# Altium schematic sheet 2023_Clippy.SchDoc
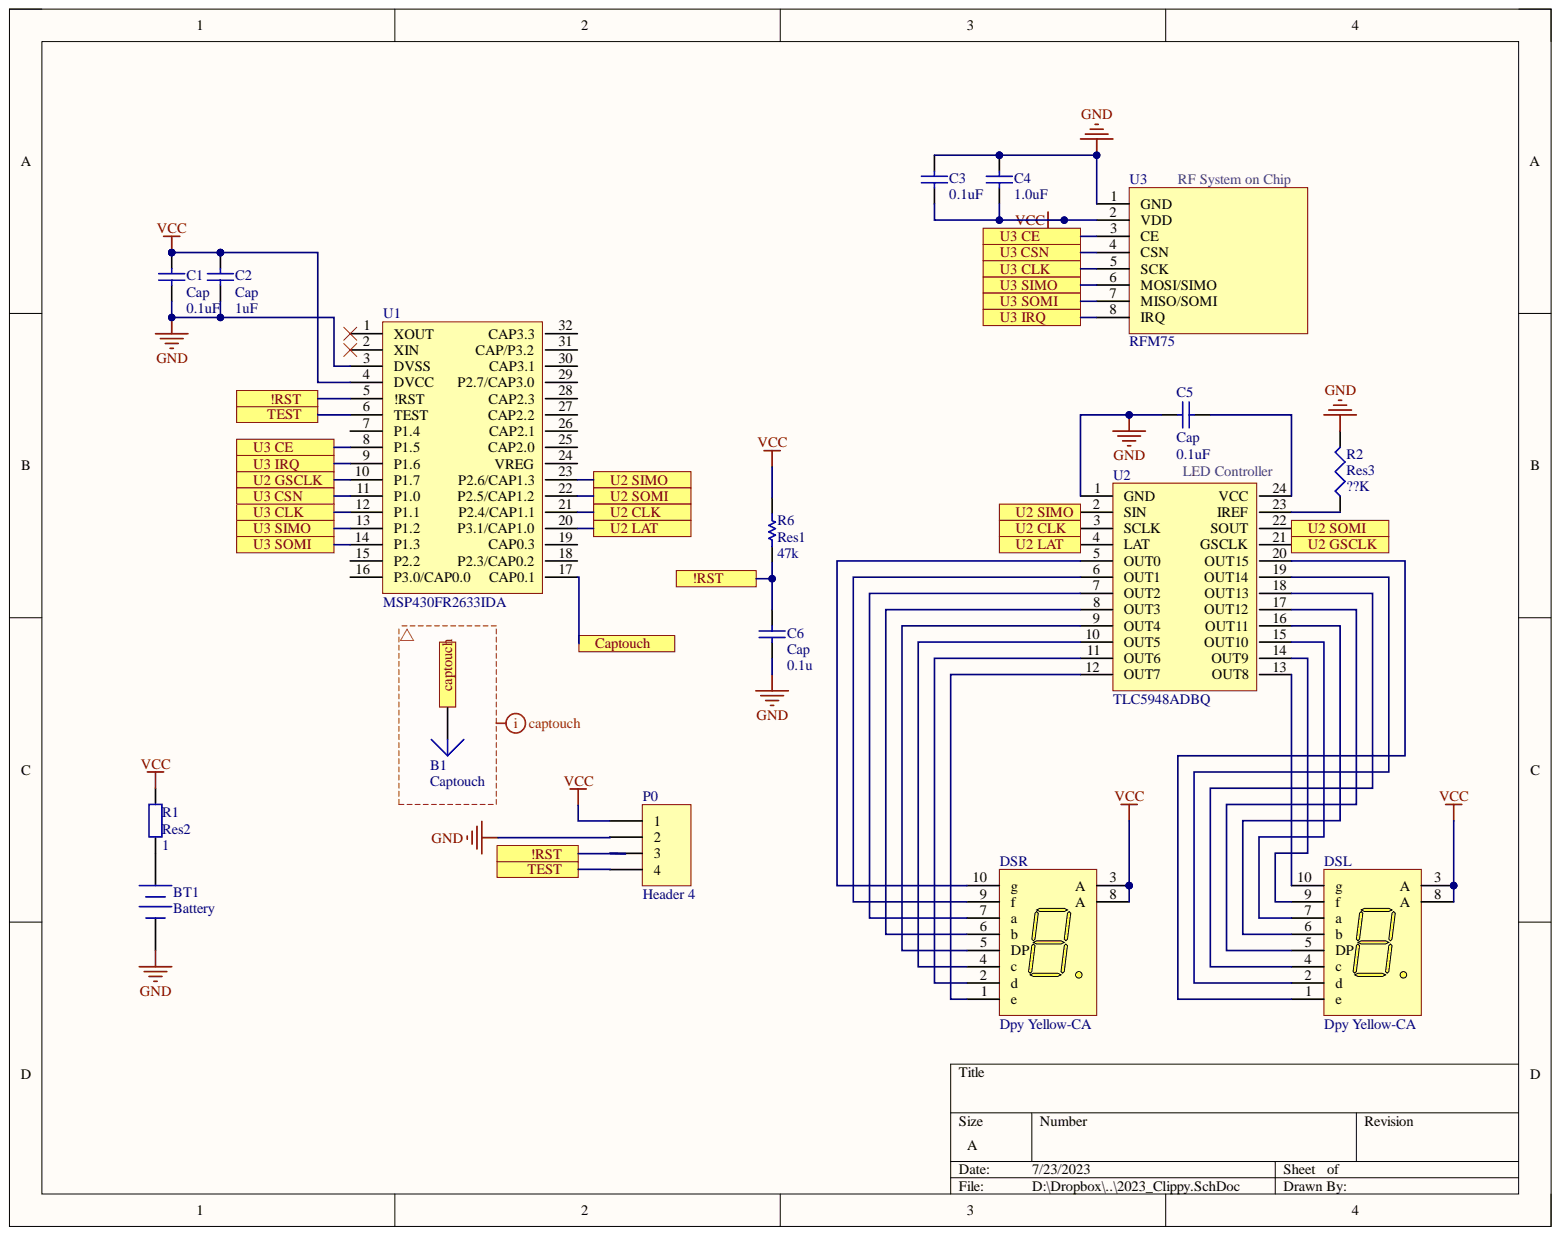
[diagram p0: 2023_Clippy.SchDoc]

## Parts
B1  Captouch  [captouch1.5mm]
BT1  Battery  [Keystone 1050]
C1  Cap  value 0.1uF  [CAPC1608(0603)]
C2  Cap  value 1uF  [CAPC1608(0603)]
C3  value 0.1uF  [CAPC1608(0603)]  (hidden comment: Cap)
C4  value 1.0uF  [CAPC1608(0603)]  (hidden comment: Cap)
C5  Cap  value 0.1uF  [CAPC1608(0603)]
C6  Cap  value 0.1u  [CAPC1608(0603)]
DSL  Dpy Yellow-CA  PackageReference: A  [7SEG]
DSR  Dpy Yellow-CA  PackageReference: A  [7SEG]
P0  Header 4  [1x4 2mm Header]
R1  Res2  value 1  [RESC5025(2010)]
R2  Res3  value ??K  [J1-0603]
R6  Res1  value 47k  [RESC1608(0603)]
U1  MSP430FR2633IDA  [TSOP65P810X120-32M]
U2  TLC5948ADBQ  [SOP64P600X175-24M]
U3  RFM75  [RFM75]

## Ports
!RST, captouch, Captouch, TEST, U2 CLK, U2 GSCLK, U2 LAT, U2 SIMO, U2 SOMI, U3 CE, U3 CLK, U3 CSN, U3 IRQ, U3 SIMO, U3 SOMI

## Nets
GND: BT1.2 C1.2 C2.1 C3.2 C4.2 C5.1 C6.1 P0.2 R2.2 U1.3(DVSS) U2.1(GND) U3.1(GND)
VCC: C1.1 C2.2 C3.1 C4.1 DSL.3(A) DSL.8(A) DSR.3(A) DSR.8(A) P0.1 R1.2 R6.2 U1.4(DVCC) U3.2(VDD)
!RST: C6.2 P0.3 R6.1 U1.5(!RST) ; port !RST
captouch: B1.1 U1.17(CAP0.1) ; port Captouch, port captouch
TEST: P0.4 U1.6(TEST) ; port TEST
U2 CLK: U1.21(P2.4/CAP1.1) U2.3(SCLK) ; port U2 CLK
U2 GSCLK: U1.10(P1.7) U2.21(GSCLK) ; port U2 GSCLK
U2 LAT: U1.20(P3.1/CAP1.0) U2.4(LAT) ; port U2 LAT
U2 SIMO: U1.23(P2.6/CAP1.3) U2.2(SIN) ; port U2 SIMO
U2 SOMI: U1.22(P2.5/CAP1.2) U2.22(SOUT) ; port U2 SOMI
U3 CE: U1.8(P1.5) U3.3(CE) ; port U3 CE
U3 CLK: U1.12(P1.1) U3.5(SCK) ; port U3 CLK
U3 CSN: U1.11(P1.0) U3.4(CSN) ; port U3 CSN
U3 IRQ: U1.9(P1.6) U3.8(IRQ) ; port U3 IRQ
U3 SIMO: U1.13(P1.2) U3.6(MOSI/SIMO) ; port U3 SIMO
U3 SOMI: U1.14(P1.3) U3.7(MISO/SOMI) ; port U3 SOMI
NetBT1_1: BT1.1 R1.1
NetC5_2: C5.2 U2.24(VCC)
NetDSL_1: DSL.1(e) U2.20(OUT15)
NetDSL_2: DSL.2(d) U2.19(OUT14)
NetDSL_4: DSL.4(c) U2.18(OUT13)
NetDSL_5: DSL.5(DP) U2.17(OUT12)
NetDSL_6: DSL.6(b) U2.16(OUT11)
NetDSL_7: DSL.7(a) U2.15(OUT10)
NetDSL_9: DSL.9(f) U2.14(OUT9)
NetDSL_10: DSL.10(g) U2.13(OUT8)
NetDSR_1: DSR.1(e) U2.12(OUT7)
NetDSR_2: DSR.2(d) U2.11(OUT6)
NetDSR_4: DSR.4(c) U2.10(OUT5)
NetDSR_5: DSR.5(DP) U2.9(OUT4)
NetDSR_6: DSR.6(b) U2.8(OUT3)
NetDSR_7: DSR.7(a) U2.7(OUT2)
NetDSR_9: DSR.9(f) U2.6(OUT1)
NetDSR_10: DSR.10(g) U2.5(OUT0)
NetR2_1: R2.1 U2.23(IREF)

Not connected: U1 1(XOUT) 2(XIN) 7(P1.4) 15(P2.2) 16(P3.0/CAP0.0) 18(P2.3/CAP0.2) 19(CAP0.3) 24(VREG) 25(CAP2.0) 26(CAP2.1) 27(CAP2.2) 28(CAP2.3) 29(P2.7/CAP3.0) 30(CAP3.1) 31(CAP/P3.2) 32(CAP3.3)

## Library data of the parts
B1 = Plug: Component Kind: Standard; Description: Plug; LatestRevisionDate: 17-Jul-2002; LatestRevisionNote: Re-released for DXP Platform.; Library Name: Miscellaneous Connectors.IntLib; Signal Integrity: Connector; Publisher: Altium Limited
BT1 = Battery: Multicell Battery; Component Kind: Standard; LatestRevisionDate: 17-Jul-2002; LatestRevisionNote: Re-released for DXP Platform.; Library Name: Miscellaneous Devices.IntLib; PackageDescription: Battery; 2 Leads; PackageReference: BAT-2; Published: 24-Mar-1999; Publisher: Altium Limited
C1-C6 = Cap: Capacitor; Component Kind: Standard; LatestRevisionDate: 17-Jul-2002; LatestRevisionNote: Re-released for DXP Platform.; Library Name: Miscellaneous Devices.IntLib; PackageDescription: Radial Cap, Thru-Hole; 2 Leads; 0.3 in Pin Spacing; PackageReference: RAD-0.3; Published: 8-Jun-2000; Signal Integrity: Cap; Simulation: CAP; Publisher: Altium Limited
DSL DSR = 7Seg: VDMX10a1; MinimumIntensity: 225 ucd; Note: Pins 1 and 6 are redundant Anodes.; Colour: Yellow; Component Kind: Standard; ComponentLink1Description: Manufacturer Link; ComponentLink1URL: http://www.agilent.com/; DatasheetVersion: 11-Feb-2002; LatestRevisionDate: 17-Dec-2003; LatestRevisionNote: Schematic revised, PCB Footprint replaced.; Library Name: 2023.SchLib; PackageDescription: LED, 7-Segment Display, 7.62 mm, RHD; 10 Leads; Row Space 5.08 mm; Pitch 2.54 mm; PackageVersion: 11-Feb-2002; Published: 8-Jun-2000; Simulation: HDSP_7401; Publisher: Altium Limited
P0 = Header 4: Header, 4-Pin; Component Kind: Standard; LatestRevisionDate: 17-Jul-2002; LatestRevisionNote: Re-released for DXP Platform.; Library Name: Miscellaneous Connectors.IntLib; Signal Integrity: Connector; Publisher: Altium Limited
R1 = Res2: Resistor; Component Kind: Standard; LatestRevisionDate: 17-Jul-2002; LatestRevisionNote: Re-released for DXP Platform.; Library Name: Miscellaneous Devices.IntLib; PackageReference: AXIAL-0.4; Published: 8-Jun-2000; Signal Integrity: Res; Simulation: RESISTOR; Publisher: Altium Limited
R2 = Res3: Resistor; Component Kind: Standard; LatestRevisionDate: 29-May-2009; LatestRevisionNote: IPC-7351 Footprint Added.; Library Name: Miscellaneous Devices.IntLib; PackageDescription: Chip Resistor; PackageReference: J1-0603; Published: 8-Jun-2000; Signal Integrity: Res; Simulation: RESISTOR; Publisher: Altium Limited
R6 = Res1: Resistor; Component Kind: Standard; LatestRevisionDate: 17-Jul-2002; LatestRevisionNote: Re-released for DXP Platform.; Library Name: Miscellaneous Devices.IntLib; PackageDescription: Axial Device, Thru-Hole; 2 Leads; 0.3 in Pin Spacing; PackageReference: AXIAL-0.3; Published: 8-Jun-2000; Signal Integrity: Res; Simulation: RESISTOR; Publisher: Altium Limited
U1 = MSP430FR2633IDA: Component Kind: Standard; Description: MSP430FR2633IDA; Library Name: 2023.SchLib
U2 = TLC5948ADBQ: Component Kind: Standard; Library Name: 2023.SchLib
U3 = RFM75: Component Kind: Standard; Library Name: Lib_2016.SchLib
